# Altium schematic sheet 1-PMOD_DVI-D.SchDoc(1-PMOD_DVI-D)
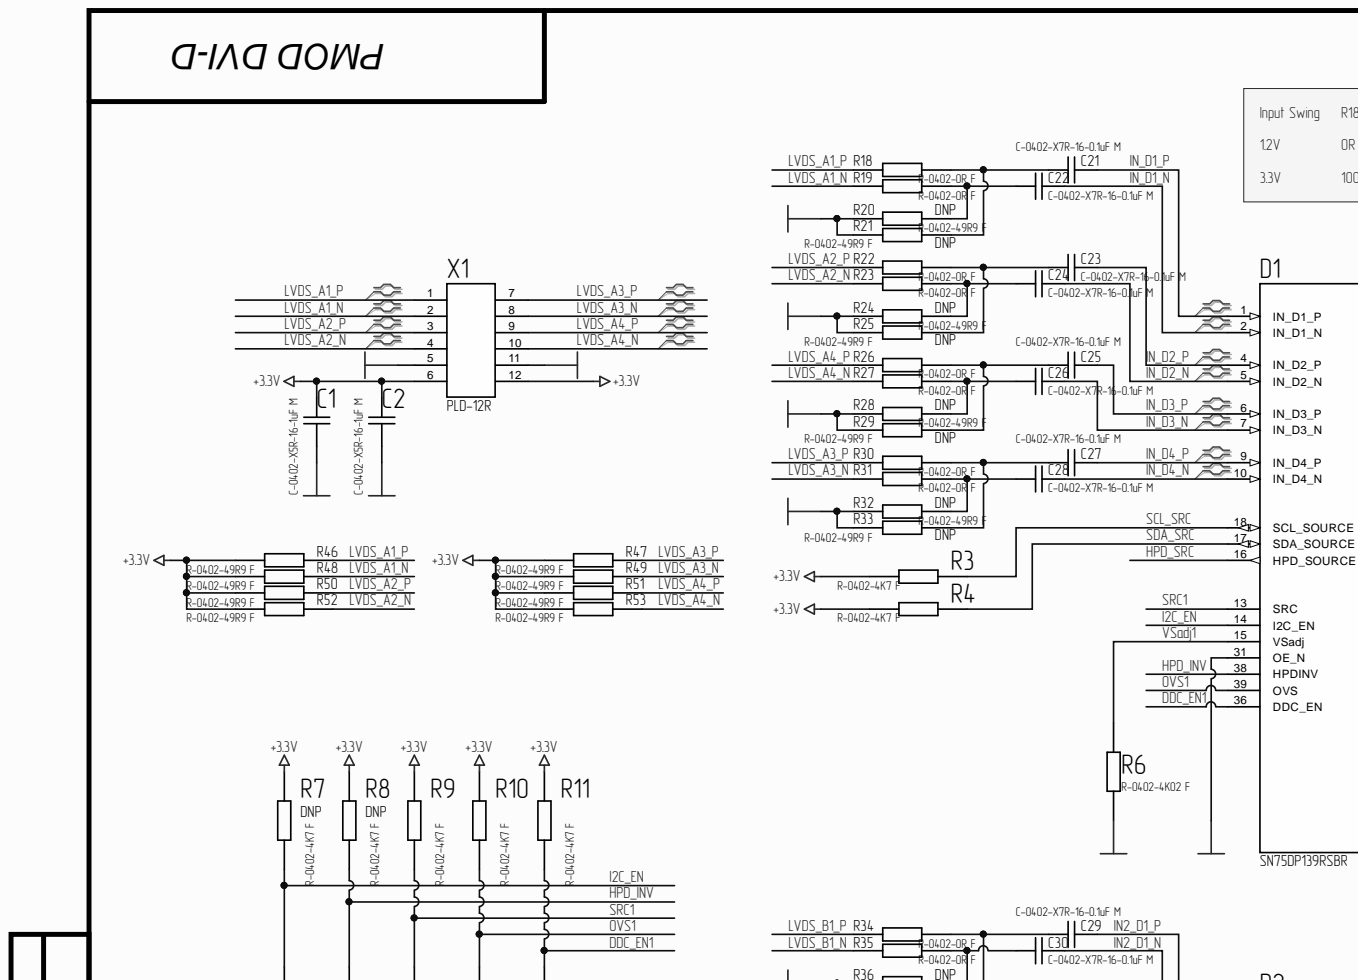
[diagram p0: 1-PMOD_DVI-D.SchDoc, top left]
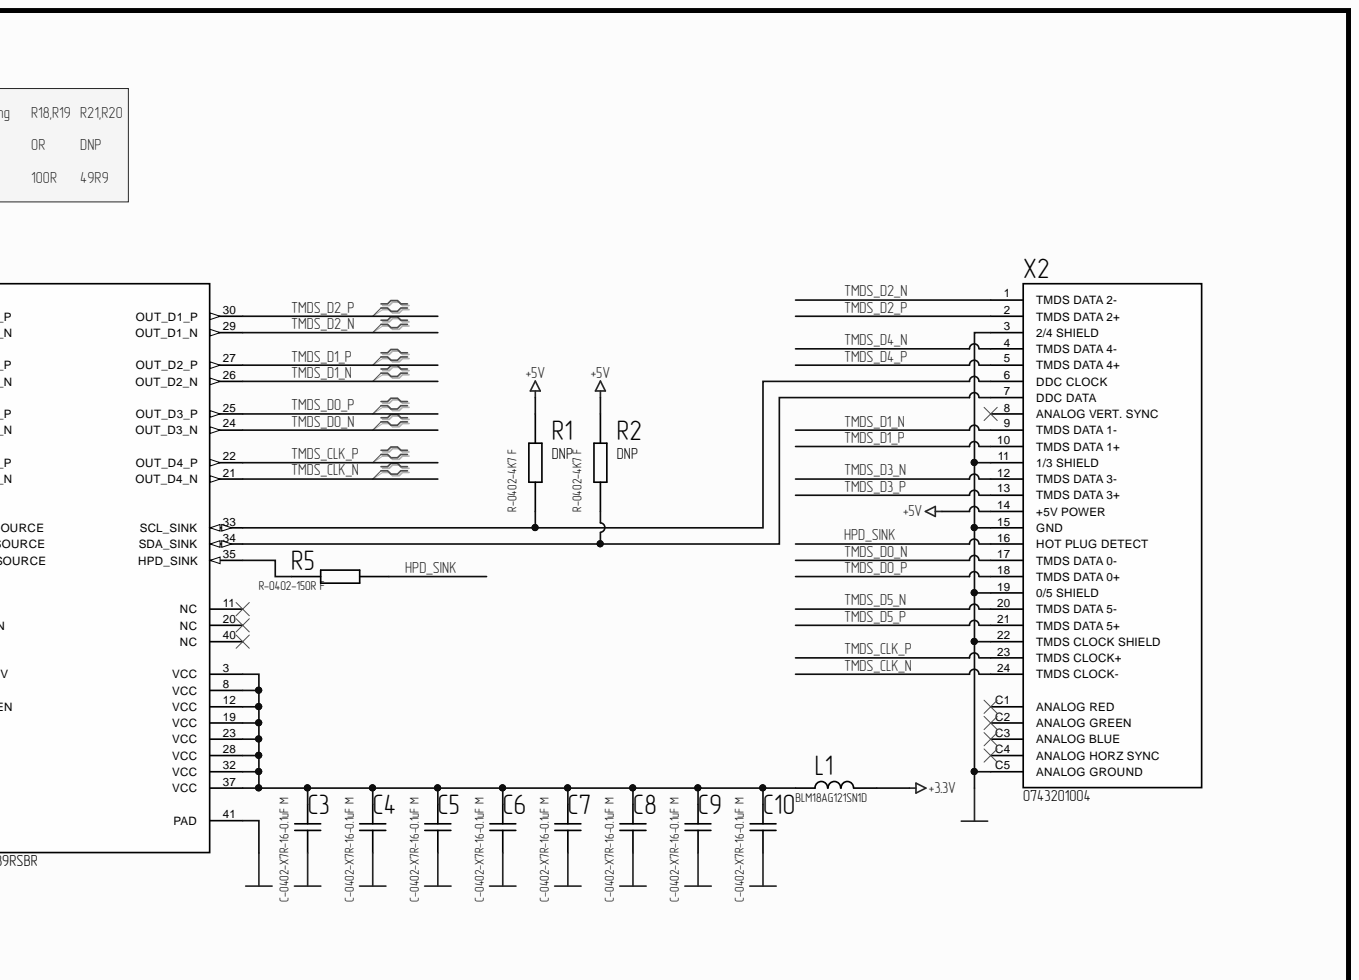
[diagram p1: 1-PMOD_DVI-D.SchDoc, top right]
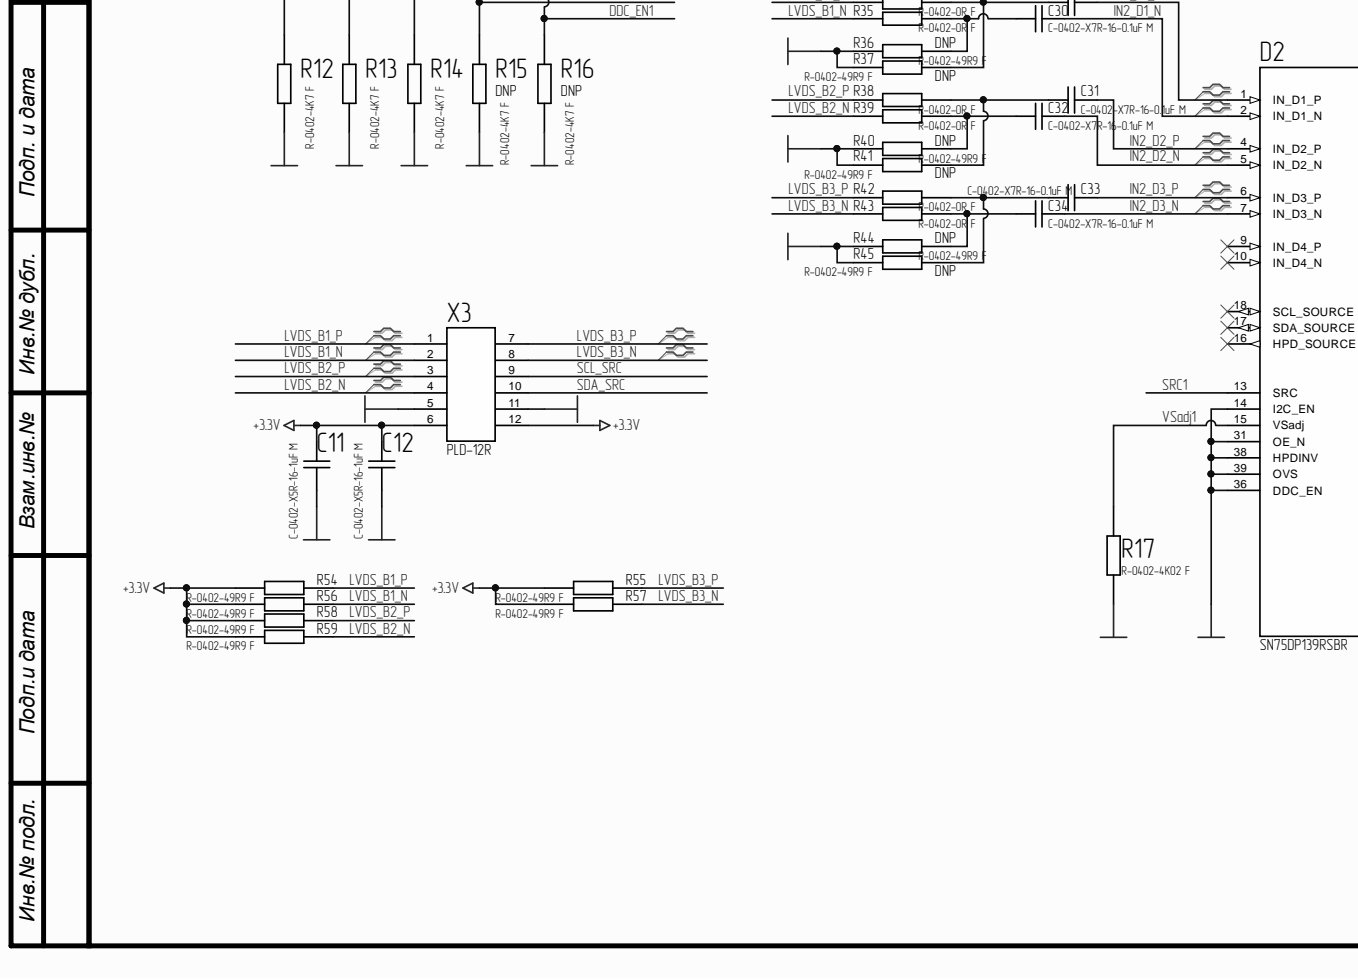
[diagram p2: 1-PMOD_DVI-D.SchDoc, bottom left]
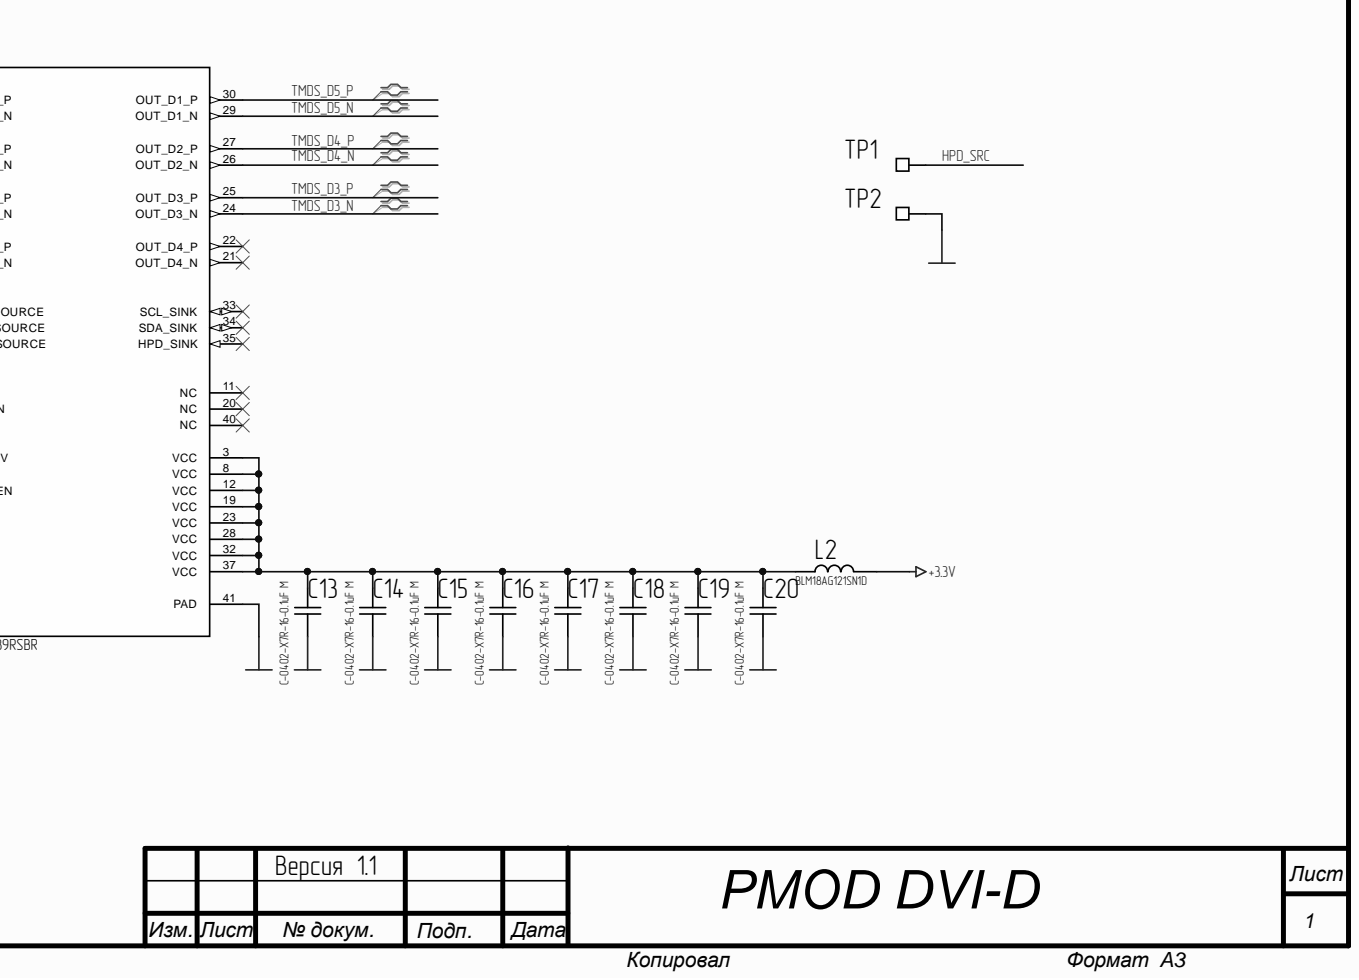
[diagram p3: 1-PMOD_DVI-D.SchDoc, bottom right]

## Parts
C1  C-0402-X5R-16-1uF M  [CAPC100X50X55L30N]
C2  C-0402-X5R-16-1uF M  [CAPC100X50X55L30N]
C3  C-0402-X7R-16-0.1uF M  [CAPC100X50X55L30N]
C4  C-0402-X7R-16-0.1uF M  [CAPC100X50X55L30N]
C5  C-0402-X7R-16-0.1uF M  [CAPC100X50X55L30N]
C6  C-0402-X7R-16-0.1uF M  [CAPC100X50X55L30N]
C7  C-0402-X7R-16-0.1uF M  [CAPC100X50X55L30N]
C8  C-0402-X7R-16-0.1uF M  [CAPC100X50X55L30N]
C9  C-0402-X7R-16-0.1uF M  [CAPC100X50X55L30N]
C10  C-0402-X7R-16-0.1uF M  [CAPC100X50X55L30N]
C11  C-0402-X5R-16-1uF M  [CAPC100X50X55L30N]
C12  C-0402-X5R-16-1uF M  [CAPC100X50X55L30N]
C13  C-0402-X7R-16-0.1uF M  [CAPC100X50X55L30N]
C14  C-0402-X7R-16-0.1uF M  [CAPC100X50X55L30N]
C15  C-0402-X7R-16-0.1uF M  [CAPC100X50X55L30N]
C16  C-0402-X7R-16-0.1uF M  [CAPC100X50X55L30N]
C17  C-0402-X7R-16-0.1uF M  [CAPC100X50X55L30N]
C18  C-0402-X7R-16-0.1uF M  [CAPC100X50X55L30N]
C19  C-0402-X7R-16-0.1uF M  [CAPC100X50X55L30N]
C20  C-0402-X7R-16-0.1uF M  [CAPC100X50X55L30N]
C21  C-0402-X7R-16-0.1uF M  [CAPC100X50X55L30N]
C22  C-0402-X7R-16-0.1uF M  [CAPC100X50X55L30N]
C23  C-0402-X7R-16-0.1uF M  [CAPC100X50X55L30N]
C24  C-0402-X7R-16-0.1uF M  [CAPC100X50X55L30N]
C25  C-0402-X7R-16-0.1uF M  [CAPC100X50X55L30N]
C26  C-0402-X7R-16-0.1uF M  [CAPC100X50X55L30N]
C27  C-0402-X7R-16-0.1uF M  [CAPC100X50X55L30N]
C28  C-0402-X7R-16-0.1uF M  [CAPC100X50X55L30N]
C29  C-0402-X7R-16-0.1uF M  [CAPC100X50X55L30N]
C30  C-0402-X7R-16-0.1uF M  [CAPC100X50X55L30N]
C31  C-0402-X7R-16-0.1uF M  [CAPC100X50X55L30N]
C32  C-0402-X7R-16-0.1uF M  [CAPC100X50X55L30N]
C33  C-0402-X7R-16-0.1uF M  [CAPC100X50X55L30N]
C34  C-0402-X7R-16-0.1uF M  [CAPC100X50X55L30N]
D1  SN75DP139RSBR  [QFN41P40_500X500X80L40X20T315N]
D2  SN75DP139RSBR  [QFN41P40_500X500X80L40X20T315N]
L1  BLM18AG121SN1D  [INDC160X80X95L40N]
L2  BLM18AG121SN1D  [INDC160X80X95L40N]
R1  R-0402-4K7 F  DoNotPlace: DNP  [RESC100X50X40L20N]
R2  R-0402-4K7 F  DoNotPlace: DNP  [RESC100X50X40L20N]
R3  R-0402-4K7 F  [RESC100X50X40L20N]
R4  R-0402-4K7 F  [RESC100X50X40L20N]
R5  R-0402-150R F  [RESC100X50X40L20N]
R6  R-0402-4K02 F  [RESC100X50X40L20N]
R7  R-0402-4K7 F  DoNotPlace: DNP  [RESC100X50X40L20N]
R8  R-0402-4K7 F  DoNotPlace: DNP  [RESC100X50X40L20N]
R9  R-0402-4K7 F  [RESC100X50X40L20N]
R10  R-0402-4K7 F  [RESC100X50X40L20N]
R11  R-0402-4K7 F  [RESC100X50X40L20N]
R12  R-0402-4K7 F  [RESC100X50X40L20N]
R13  R-0402-4K7 F  [RESC100X50X40L20N]
R14  R-0402-4K7 F  [RESC100X50X40L20N]
R15  R-0402-4K7 F  DoNotPlace: DNP  [RESC100X50X40L20N]
R16  R-0402-4K7 F  DoNotPlace: DNP  [RESC100X50X40L20N]
R17  R-0402-4K02 F  [RESC100X50X40L20N]
R18  R-0402-0R F  [RESC100X50X40L20N]
R19  R-0402-0R F  [RESC100X50X40L20N]
R20  R-0402-49R9 F  DoNotPlace: DNP  [RESC100X50X40L20N]
R21  R-0402-49R9 F  DoNotPlace: DNP  [RESC100X50X40L20N]
R22  R-0402-0R F  [RESC100X50X40L20N]
R23  R-0402-0R F  [RESC100X50X40L20N]
R24  R-0402-49R9 F  DoNotPlace: DNP  [RESC100X50X40L20N]
R25  R-0402-49R9 F  DoNotPlace: DNP  [RESC100X50X40L20N]
R26  R-0402-0R F  [RESC100X50X40L20N]
R27  R-0402-0R F  [RESC100X50X40L20N]
R28  R-0402-49R9 F  DoNotPlace: DNP  [RESC100X50X40L20N]
R29  R-0402-49R9 F  DoNotPlace: DNP  [RESC100X50X40L20N]
R30  R-0402-0R F  [RESC100X50X40L20N]
R31  R-0402-0R F  [RESC100X50X40L20N]
R32  R-0402-49R9 F  DoNotPlace: DNP  [RESC100X50X40L20N]
R33  R-0402-49R9 F  DoNotPlace: DNP  [RESC100X50X40L20N]
R34  R-0402-0R F  [RESC100X50X40L20N]
R35  R-0402-0R F  [RESC100X50X40L20N]
R36  R-0402-49R9 F  DoNotPlace: DNP  [RESC100X50X40L20N]
R37  R-0402-49R9 F  DoNotPlace: DNP  [RESC100X50X40L20N]
R38  R-0402-0R F  [RESC100X50X40L20N]
R39  R-0402-0R F  [RESC100X50X40L20N]
R40  R-0402-49R9 F  DoNotPlace: DNP  [RESC100X50X40L20N]
R41  R-0402-49R9 F  DoNotPlace: DNP  [RESC100X50X40L20N]
R42  R-0402-0R F  [RESC100X50X40L20N]
R43  R-0402-0R F  [RESC100X50X40L20N]
R44  R-0402-49R9 F  DoNotPlace: DNP  [RESC100X50X40L20N]
R45  R-0402-49R9 F  DoNotPlace: DNP  [RESC100X50X40L20N]
R46  R-0402-49R9 F  [RESC100X50X40L20N]
R47  R-0402-49R9 F  [RESC100X50X40L20N]
R48  R-0402-49R9 F  [RESC100X50X40L20N]
R49  R-0402-49R9 F  [RESC100X50X40L20N]
R50  R-0402-49R9 F  [RESC100X50X40L20N]
R51  R-0402-49R9 F  [RESC100X50X40L20N]
R52  R-0402-49R9 F  [RESC100X50X40L20N]
R53  R-0402-49R9 F  [RESC100X50X40L20N]
R54  R-0402-49R9 F  [RESC100X50X40L20N]
R55  R-0402-49R9 F  [RESC100X50X40L20N]
R56  R-0402-49R9 F  [RESC100X50X40L20N]
R57  R-0402-49R9 F  [RESC100X50X40L20N]
R58  R-0402-49R9 F  [RESC100X50X40L20N]
R59  R-0402-49R9 F  [RESC100X50X40L20N]
TP1  Testpoint  [TEST_POINT_1_25mm]  (value not drawn)  (no BOM)
TP2  Testpoint  [TEST_POINT_1_25mm]  (value not drawn)  (no BOM)
X1  PLD-12R  [HDRRA12W64P254_6X2_1524X254H508]
X2  0743201004  [74320-1004]
X3  PLD-12R  [HDRRA12W64P254_6X2_1524X254H508]

## Nets
+3.3V: C1.1 C2.1 C11.1 C12.1 L1.2 L2.2 R3.1 R4.1 R7.1 R8.1 R9.1 R10.1 R11.1 R46.1 R47.1 R48.1 R49.1 R50.1 R51.1 R52.1 R53.1 R54.1 R55.1 R56.1 R57.1 R58.1 R59.1 X1.6 X1.12 X3.6 X3.12
+5V: R1.1 R2.1 X2.14(+5V_POWER)
GND: C1.2 C2.2 C3.2 C4.2 C5.2 C6.2 C7.2 C8.2 C9.2 C10.2 C11.2 C12.2 C13.2 C14.2 C15.2 C16.2 C17.2 C18.2 C19.2 C20.2 D1.31(OE_N) D1.41(PAD) D2.14(I2C_EN) D2.31(OE_N) D2.36(DDC_EN) D2.38(HPDINV) D2.39(OVS) D2.41(PAD) R6.2 R12.2 R13.2 R14.2 R15.2 R16.2 R17.2 R20.1 R21.1 R24.1 R25.1 R28.1 R29.1 R32.1 R33.1 R36.1 R37.1 R40.1 R41.1 R44.1 R45.1 TP2.1 X1.5 X1.11 X2.3(2/4_SHIELD) X2.11(1/3_SHIELD) X2.15(GND) X2.19(0/5_SHIELD) X2.22(TMDS_CLOCK_SHIELD) X2.C5(ANALOG_GROUND) X3.5 X3.11
DDC_EN1: D1.36(DDC_EN) R11.2 R16.1
HPD_INV: D1.38(HPDINV) R8.2 R13.1
HPD_SINK: R5.1 X2.16(HOT_PLUG_DETECT)
HPD_SRC: D1.16(HPD_SOURCE) TP1.1
I2C_EN: D1.14(I2C_EN) R7.2 R12.1
IN2_D1_N: C30.2 D2.2(IN_D1_N)
IN2_D1_P: C29.2 D2.1(IN_D1_P)
IN2_D2_N: C32.2 D2.5(IN_D2_N)
IN2_D2_P: C31.2 D2.4(IN_D2_P)
IN2_D3_N: C34.2 D2.7(IN_D3_N)
IN2_D3_P: C33.2 D2.6(IN_D3_P)
IN_D1_N: C22.2 D1.2(IN_D1_N)
IN_D1_P: C21.2 D1.1(IN_D1_P)
IN_D2_N: C24.2 D1.5(IN_D2_N)
IN_D2_P: C23.2 D1.4(IN_D2_P)
IN_D3_N: C26.2 D1.7(IN_D3_N)
IN_D3_P: C25.2 D1.6(IN_D3_P)
IN_D4_N: C28.2 D1.10(IN_D4_N)
IN_D4_P: C27.2 D1.9(IN_D4_P)
LVDS_A1_N: R19.1 R48.2 X1.2
LVDS_A1_P: R18.1 R46.2 X1.1
LVDS_A2_N: R23.1 R52.2 X1.4
LVDS_A2_P: R22.1 R50.2 X1.3
LVDS_A3_N: R31.1 R49.2 X1.8
LVDS_A3_P: R30.1 R47.2 X1.7
LVDS_A4_N: R27.1 R53.2 X1.10
LVDS_A4_P: R26.1 R51.2 X1.9
LVDS_B1_N: R35.1 R56.2 X3.2
LVDS_B1_P: R34.1 R54.2 X3.1
LVDS_B2_N: R39.1 R59.2 X3.4
LVDS_B2_P: R38.1 R58.2 X3.3
LVDS_B3_N: R43.1 R57.2 X3.8
LVDS_B3_P: R42.1 R55.2 X3.7
OVS1: D1.39(OVS) R10.2 R15.1
SCL_SRC: D1.18(SCL_SOURCE) R3.2 X3.9
SDA_SRC: D1.17(SDA_SOURCE) R4.2 X3.10
SRC1: D1.13(SRC) D2.13(SRC) R9.2 R14.1
TMDS_CLK_N: D1.21(OUT_D4_N) X2.24(TMDS_CLOCK-)
TMDS_CLK_P: D1.22(OUT_D4_P) X2.23(TMDS_CLOCK+)
TMDS_D0_N: D1.24(OUT_D3_N) X2.17(TMDS_DATA_0-)
TMDS_D0_P: D1.25(OUT_D3_P) X2.18(TMDS_DATA_0+)
TMDS_D1_N: D1.26(OUT_D2_N) X2.9(TMDS_DATA_1-)
TMDS_D1_P: D1.27(OUT_D2_P) X2.10(TMDS_DATA_1+)
TMDS_D2_N: D1.29(OUT_D1_N) X2.1(TMDS_DATA_2-)
TMDS_D2_P: D1.30(OUT_D1_P) X2.2(TMDS_DATA_2+)
TMDS_D3_N: D2.24(OUT_D3_N) X2.12(TMDS_DATA_3-)
TMDS_D3_P: D2.25(OUT_D3_P) X2.13(TMDS_DATA_3+)
TMDS_D4_N: D2.26(OUT_D2_N) X2.4(TMDS_DATA_4-)
TMDS_D4_P: D2.27(OUT_D2_P) X2.5(TMDS_DATA_4+)
TMDS_D5_N: D2.29(OUT_D1_N) X2.20(TMDS_DATA_5-)
TMDS_D5_P: D2.30(OUT_D1_P) X2.21(TMDS_DATA_5+)
VSadj1: D1.15(VSadj) D2.15(VSadj) R6.1 R17.1
NetC3_1: C3.1 C4.1 C5.1 C6.1 C7.1 C8.1 C9.1 C10.1 D1.3(VCC) D1.8(VCC) D1.12(VCC) D1.19(VCC) D1.23(VCC) D1.28(VCC) D1.32(VCC) D1.37(VCC) L1.1
NetC13_1: C13.1 C14.1 C15.1 C16.1 C17.1 C18.1 C19.1 C20.1 D2.3(VCC) D2.8(VCC) D2.12(VCC) D2.19(VCC) D2.23(VCC) D2.28(VCC) D2.32(VCC) D2.37(VCC) L2.1
NetC21_1: C21.1 R18.2 R21.2
NetC22_1: C22.1 R19.2 R20.2
NetC23_1: C23.1 R22.2 R25.2
NetC24_1: C24.1 R23.2 R24.2
NetC25_1: C25.1 R26.2 R29.2
NetC26_1: C26.1 R27.2 R28.2
NetC27_1: C27.1 R30.2 R33.2
NetC28_1: C28.1 R31.2 R32.2
NetC29_1: C29.1 R34.2 R37.2
NetC30_1: C30.1 R35.2 R36.2
NetC31_1: C31.1 R38.2 R41.2
NetC32_1: C32.1 R39.2 R40.2
NetC33_1: C33.1 R42.2 R45.2
NetC34_1: C34.1 R43.2 R44.2
NetD1_33: D1.33(SCL_SINK) R1.2 X2.6(DDC_CLOCK)
NetD1_34: D1.34(SDA_SINK) R2.2 X2.7(DDC_DATA)
NetD1_35: D1.35(HPD_SINK) R5.2

Not connected: D1 11(NC) 20(NC) 40(NC); D2 9(IN_D4_P) 10(IN_D4_N) 11(NC) 16(HPD_SOURCE) 17(SDA_SOURCE) 18(SCL_SOURCE) 20(NC) 21(OUT_D4_N) 22(OUT_D4_P) 33(SCL_SINK) 34(SDA_SINK) 35(HPD_SINK) 40(NC); X2 8(ANALOG_VERT._SYNC) C1(ANALOG_RED) C2(ANALOG_GREEN) C3(ANALOG_BLUE) C4(ANALOG_HORZ_SYNC)

## Library data of the parts
C1 C2 C11 C12 = C: General capacitor; Manufacturer: Murata; Voltage: 16V; Value: 1uF; Tolerance: 20%; TC: X5R; Mount_Type: SMD; Component_Type: Конденсатор; UID: C-0402-X5R-16-1uF M; Size: 0402; PnP_Name: C-0402-X5R-16-1uF M; Library Name: Passive.SchLib; Component Kind: Standard
C3-C10 C13-C34 = C: General capacitor; Manufacturer: Murata; Voltage: 16V; Value: 0.1uF; Tolerance: 20%; TC: X7R; Mount_Type: SMD; Component_Type: Конденсатор; UID: C-0402-X7R-16-0.1uF M; Size: 0402; PnP_Name: C-0402-X7R-16-0.1uF M; Library Name: Passive.SchLib; Component Kind: Standard
D1 D2 = SN75DP139RSBR: DisplayPort to TMDS Translator; Manufacturer: Texas Instruments; PnP_Name: D-SN75DP139RSBR; Mount_Type: Auto; Component_Type: Микросхема; UID: D-SN75DP139RSBR; Partnumber: SN75DP139RSBR; Library Name: ICs.SchLib; Component Kind: Standard
L1 L2 = L: General inductor; Manufacturer: Murata; Value: 120R; PnP_Name: F-BLM18AG121SN1D; Mount_Type: SMD; MaxCurrent: 0.5A; Component_Type: Фильтр; UID: F-BLM18AG121SN1D; Size: 0603; Partnumber: BLM18AG121SN1D; Library Name: Passive.SchLib; Component Kind: Standard
R1-R4 R7-R16 = R: General resistor; Manufacturer: Yageo; Value: 4.7k; Tolerance: 1%; Mount_Type: Auto; Component_Type: Резистор; UID: R-0402-4K7 F; Size: 0402; PnP_Name: R-0402-4K7 F; Library Name: Passive.SchLib; Component Kind: Standard
R5 = R: General resistor; Manufacturer: Yageo; Value: 150; Tolerance: 1%; Mount_Type: Auto; Component_Type: Резистор; UID: R-0402-150R F; Size: 0402; PnP_Name: R-0402-150R F; Library Name: Passive.SchLib; Component Kind: Standard
R6 R17 = R: General resistor; Manufacturer: Yageo; Value: 4.02k; Tolerance: 1%; Mount_Type: Auto; Component_Type: Резистор; UID: R-0402-4K02 F; Size: 0402; PnP_Name: R-0402-4K02 F; Library Name: Passive.SchLib; Component Kind: Standard
R18 R19 R22 R23 R26 R27 R30 R31 R34 R35 R38 R39 R42 R43 = R: General resistor; Manufacturer: Yageo; Value: 0; Mount_Type: Auto; Component_Type: Резистор; UID: R-0402-0R F; Tolerance: -; Size: 0402; PnP_Name: R-0402-0R F; Library Name: Passive.SchLib; Component Kind: Standard
R20 R21 R24 R25 R28 R29 R32 R33 R36 R37 R40 R41 R44-R59 = R: General resistor; Manufacturer: Yageo; Value: 49.9; Tolerance: 1%; Mount_Type: Auto; Component_Type: Резистор; UID: R-0402-49R9 F; Size: 0402; PnP_Name: R-0402-49R9 F; Library Name: Passive.SchLib; Component Kind: Standard
TP1 TP2 = Test_point: Test point; Library Name: Main_2019.SchLib
X1 X3 = Conn_2x6_PMOD: Mount_Type: Manual; Component_Type: Разъем; UID: X-PLD-12R; Partnumber: PLD-12R; Manufacturer: -; Library Name: Connectors.SchLib; Component Kind: Standard
X2 = DVI-I_dual: Manufacturer: Molex; Mount_Type: Manual; Component_Type: Соединитель; UID: X-0743201004; Partnumber: 0743201004; Library Name: Connectors.SchLib; Component Kind: Standard
